# Altium schematic sheet SDEC945-xB_SD_Card.SchDoc(SDEC945-xB_SD_Card)
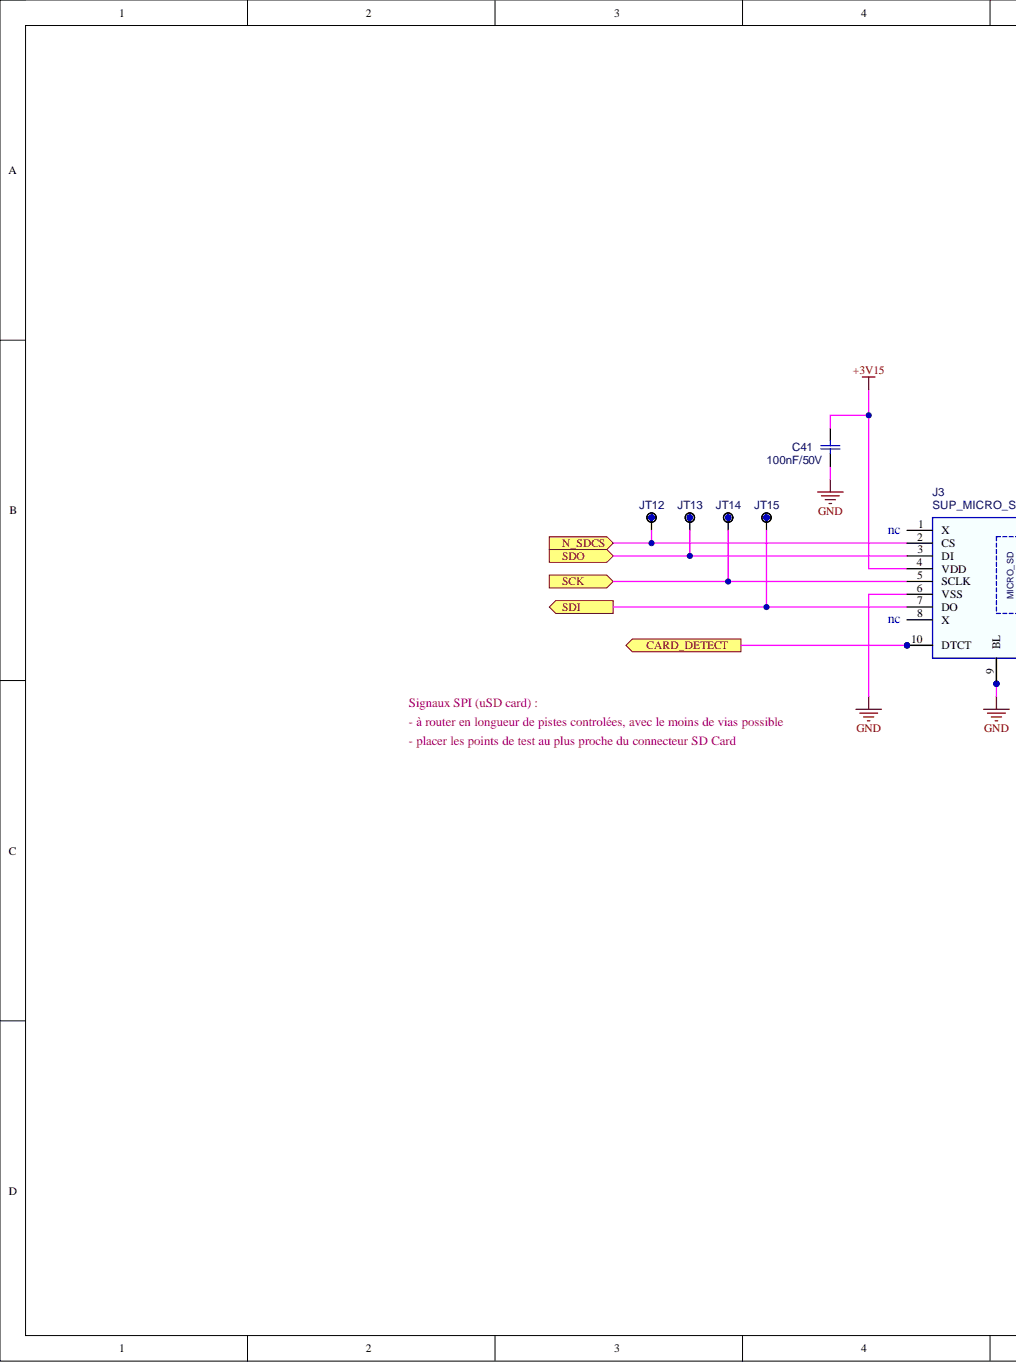
[diagram p0: SDEC945-xB_SD_Card.SchDoc, left]
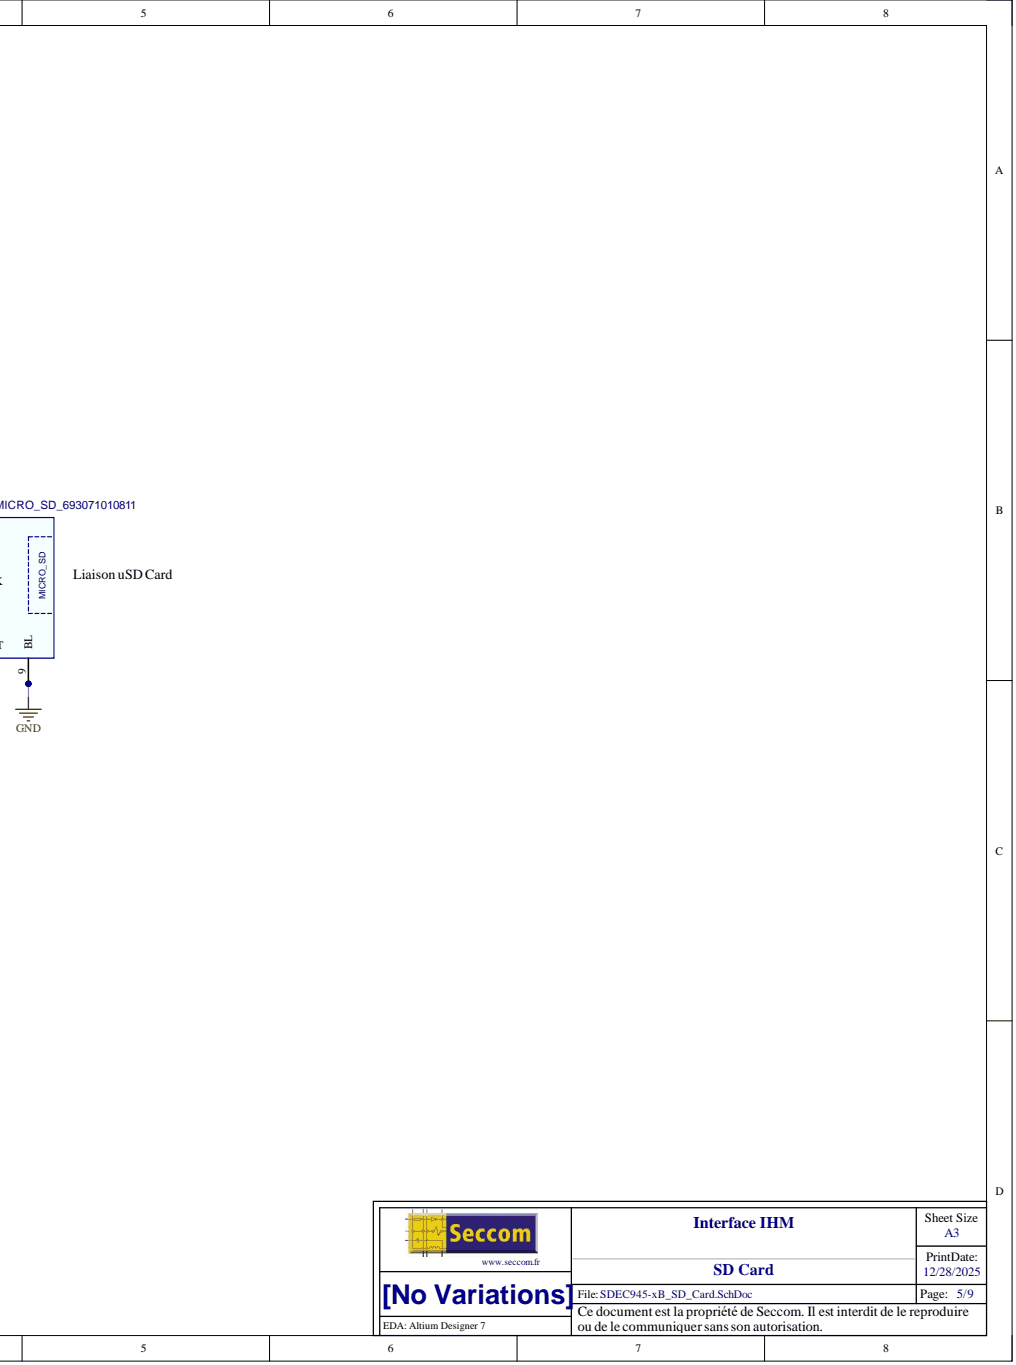
[diagram p1: SDEC945-xB_SD_Card.SchDoc, right]

## Parts
C41  value 100nF/50V  (hidden comment: C_0603_X7R_10%_100N-50V)
J3  value SUP_MICRO_SD_693071010811  (hidden comment: SUP_MICRO_SD_CARD_BL_PUSH-PUSH_693071010811)
JT12  POINT_TEST_PAD_40MIL  (value not drawn)  (no BOM)
JT13  POINT_TEST_PAD_40MIL  (value not drawn)  (no BOM)
JT14  POINT_TEST_PAD_40MIL  (value not drawn)  (no BOM)
JT15  POINT_TEST_PAD_40MIL  (value not drawn)  (no BOM)

## Ports
CARD_DETECT, N_SDCS, SCK, SDI, SDO

## Nets
+3V15: C41.2 J3.4(VDD)
GND: C41.1 J3.6(VSS) J3.9(BL) J3.9A
CARD_DETECT: J3.10 J3.10A(DTCT) ; port CARD_DETECT
N_SDCS: J3.2(CS) JT12.1 ; port N_SDCS
SCK: J3.5(SCLK) JT14.1 ; port SCK
SDI: J3.7(DO) JT15.1 ; port SDI
SDO: J3.3(DI) JT13.1 ; port SDO

Not connected: J3 1(X) 8(X)

## Library data of the parts
C41 = C_0603_X7R_10%_100N-50V: Condensateur céramique, X7R, 10%, CMS 0603, 100nF/50V; Boitier: 0603; Coeff: 1; Creation: FB (29/02/12); Fabricant: Kemet; Ref Fab: C0603C104K5RAC; Remarque: {Remarque}; Component Kind: Standard; ComponentLink1Description: Datasheet; ComponentLink2Description: Datasheet Boitier; Library Name: SC94x.SchLib; Supplier 1: Farnell; Supplier Part Number 1: 1288255; ComponentLink1URL: O:\_Documentation\Datasheets\Kemet\Condos_Ceramic_CMS.pdf; ComponentLink2URL: o:\_Documentation\Datasheets\_BOITIERS\PASSIF_CMS\
J3 = SUP_MICRO_SD_CARD_BL_PUSH-PUSH_693071010811: Embase pour Micro SD Card, 8 points, blindée, fixation Push-Push, noir, -25/+85°C, CMS; Boitier: 14x15.2+1.7mm_h1.98mm; Coeff: 1; Creation: FB (05/03/12); Fabricant: Wurth Elektronik; Component Kind: Standard; ComponentLink1Description: Datasheet; ComponentLink2Description: Datasheet Boitier; Library Name: SC94x.SchLib; Ref Fab: 693071010811; Supplier 1: Farnell; Supplier Part Number 1: 2081363; ComponentLink1URL: O:\_Documentation\Datasheets\Wurth_Elektronik\693071010811.pdf; ComponentLink2URL: o:\_Documentation\Datasheets\_BOITIERS\
JT12-JT15 = POINT_TEST_PAD_40MIL: Point de test sur CI, pad de diamètre 1.016mm (40mils); Coeff: 1; Creation: FB (04/10/12); Remarque: {Remarque}; ComponentLink1Description: Datasheet; ComponentLink2Description: Datasheet Boitier; Value: POINT_TEST; Library Name: CONN_PICOT.SchLib
